# Altium schematic sheet 30082017.SchDoc
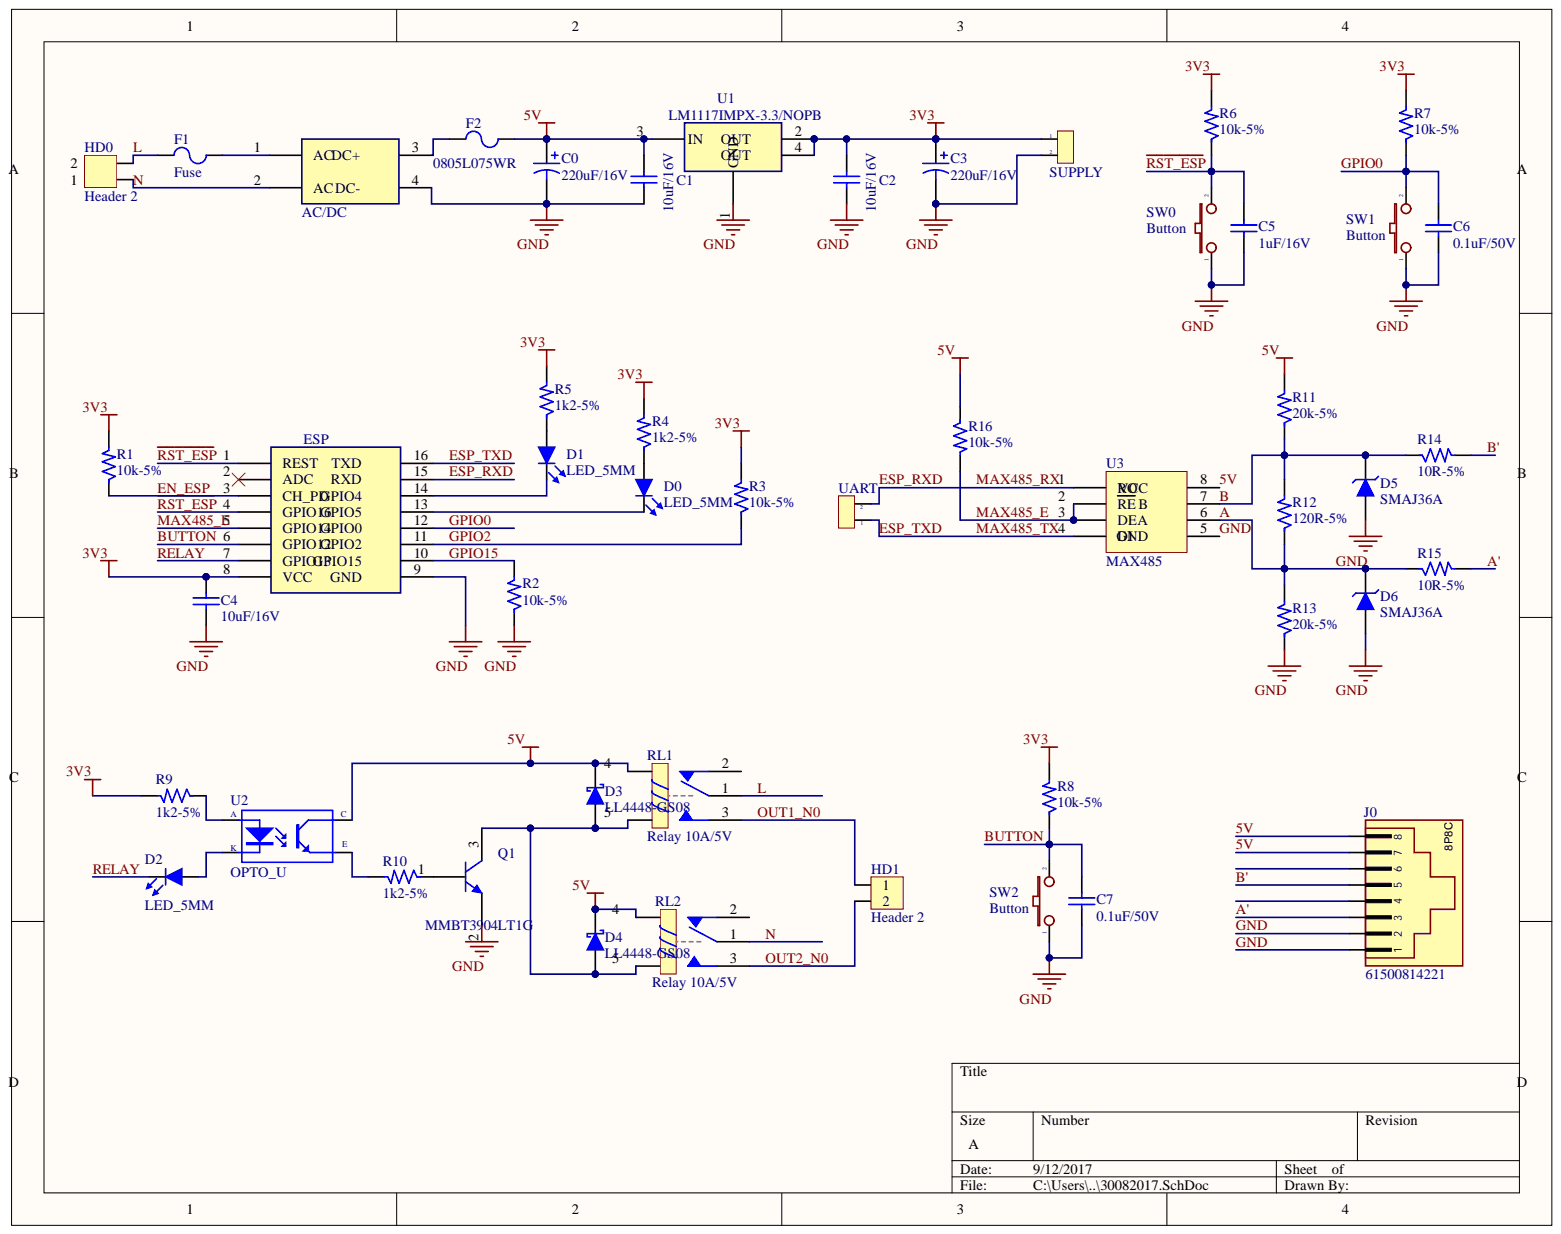
[diagram p0: 30082017.SchDoc]

## Parts
AC/DC  AC/DC_5V_0.7A  [AC/DC_5V_700mA]  (value not drawn)
C0  220uF/16V  [RADIAL_6.3X12]
C1  10uF/16V  [CAP_SMD_0805]
C2  10uF/16V  [CAP_SMD_0805]
C3  220uF/16V  [RADIAL_6.3X12]
C4  10uF/16V  [CAP_SMD_0805]
C5  1uF/16V  [CAP_SMD_0805]
C6  0.1uF/50V  [CAP_SMD_0805]
C7  0.1uF/50V  [CAP_SMD_0805]
D0  LED_5MM  [LED_5mm]
D1  LED_5MM  [LED_5mm]
D2  LED_5MM  [LED_5mm]
D3  LL4448-GS08  [SOD80C]
D4  LL4448-GS08  [SOD80C]
D5  SMAJ36A  [DO-214AC (SMA)]
D6  SMAJ36A  [DO-214AC (SMA)]
ESP  ESP8266_07  [ESP8266_07]  (value not drawn)
F1  Fuse  [RESISTOR 1/4W (STAND)]
F2  0805L075WR  [RES_SMD_0805]
HD0  Header 2  [HED2]
HD1  Header 2  [HED2]
J0  61500814221  PinNumber: 8P8C  [61500814221]
Q1  MMBT3904LT1G  [SOT-23]
R1  10k-5%  [RES_SMD_0805]
R2  10k-5%  [RES_SMD_0805]
R3  10k-5%  [RES_SMD_0805]
R4  1k2-5%  [RES_SMD_0805]
R5  1k2-5%  [RES_SMD_0805]
R6  10k-5%  [RES_SMD_0805]
R7  10k-5%  [RES_SMD_0805]
R8  10k-5%  [RES_SMD_0805]
R9  1k2-5%  [RES_SMD_0805]
R10  1k2-5%  [RES_SMD_0805]
R11  20k-5%  [RES_SMD_0805]
R12  120R-5%  [RES_SMD_0805]
R13  20k-5%  [RES_SMD_0805]
R14  10R-5%  [RES_SMD_0805]
R15  10R-5%  [RES_SMD_0805]
R16  10k-5%  [RES_SMD_0805]
RL1  Relay 10A/5V  [RELAY5PIN-10A]
RL2  Relay 10A/5V  [RELAY5PIN-10A]
SUPPLY  Jumper 2  [JP2]  (value not drawn)
SW0  Button  [SW2]
SW1  Button  [SW2]
SW2  Button  [SW2]
U1  LM1117IMPX-3.3/NOPB  [SOT223]
U2  OPTO_U  [DIP4/W0.3]
U3  MAX485  [SO-8]
UART  Jumper 2  [JP2]  (value not drawn)

## Nets
3V3: C2.2 C3.1 C4.2 ESP.8(VCC) R1.2 R3.2 R4.2 R5.2 R6.2 R7.2 R8.2 R9.2 SUPPLY.1 U1.2 U1.4
5V: C0.1 C1.2 D3.2 D4.2 F2.2 J0.7 J0.8 R11.2 R16.1 RL1.4 RL2.4 U1.3(IN) U2.4 U3.8
A: D6.2 R12.1 R13.2 R15.1 U3.6
A': J0.3 R15.2
B: D5.2 R11.1 R12.2 R14.1 U3.7
B': J0.5 R14.2
BUTTON: C7.2 ESP.6(GPIO12) R8.1 SW2.2
EN_ESP: ESP.3(CH_PD) R1.1
ESP_RXD: ESP.15 U3.1(RO) UART.2
ESP_TXD: ESP.16 U3.4(GND) UART.1
GND: AC/DC.4 C0.2 C1.1 C2.1 C3.2 C4.1 C5.1 C6.1 C7.1 D5.1 D6.1 ESP.9 J0.1 J0.2 Q1.2 R2.1 R13.1 SUPPLY.2 SW0.1 SW1.1 SW2.1 U1.1(GND) U3.5
GPIO0: C6.2 ESP.12 R7.1 SW1.2
GPIO2: ESP.11 R3.1
GPIO15: ESP.10 R2.2
L: F1.1 HD0.2 RL1.1
MAX485_E: ESP.5(GPIO14) R16.2 U3.2(RE_B) U3.3(DE_A)
N: AC/DC.2(AC_DC-) HD0.1 RL2.1
OUT1_N0: HD1.1 RL1.3
OUT2_N0: HD1.2 RL2.3
~{RST_ESP}: C5.2 ESP.1(REST) ESP.4(GPIO16) R6.1 SW0.2
RELAY: D2.2 ESP.7(GPIO13)
NetAC/DC_1: AC/DC.1(AC) F1.2
NetAC/DC_3: AC/DC.3 F2.1
NetD0_1: D0.1 R4.1
NetD0_2: D0.2 ESP.13
NetD1_1: D1.1 R5.1
NetD1_2: D1.2 ESP.14
NetD2_1: D2.1 U2.2
NetD3_1: D3.1 D4.1 Q1.3 RL1.5 RL2.5
NetQ1_1: Q1.1 R10.1
NetR9_1: R9.1 U2.1
NetR10_2: R10.2 U2.3

Not connected: ESP 2(ADC); J0 4 6; RL1 2; RL2 2

## Library data of the parts
AC/DC = AC/DC_5V_0.7A: AC/DC Converter 5V 700mA; Component Kind: Standard; ComponentLink1URL: http://www.dientuachau.com/ac-dc-5v-700; Library Name: LIF_DIF_Library.IntLib
C0 C3 = 100uF/25V: CAP ALUM 100UF 20% 25V RADIAL; Manufacturer: Panasonic Electronic Components; Manufacturer Part Number: ECA-1EM101; Capacitance: 100µF; Lifetime @ Temp.: 2000 Hrs @ 85°C; Polarization: Polar; Ripple Current - High Frequency: 306mA @ 10kHz; Ripple Current - Low Frequency: 180mA @ 120Hz; Applications: General Purpose; Category: Capacitors; Component Kind: Standard; ComponentLink1Description: https://industrial.panasonic.com/cdbs/www-data/pdf/RDF0000/ABA0000C1218.pdf; ComponentLink1URL: https://industrial.panasonic.com/cdbs/www-data/pdf/RDF0000/ABA0000C1218.pdf; ESR (Equivalent Series Resistance): -; Height - Seated (Max): 0.480" (12.20mm); Impedance: -; Lead Spacing: 0.098" (2.50mm); Mounting Type: Through Hole; Operating Temperature: -40°C ~ 85°C; Package / Case: Radial, Can; Packaging: Bulk; Part Status: Active; Pricing 1: 1=0.28, 10=0.191, 100=0.097, 500=0.06634, 1000=0.05868, 2500=0.05613, 5000=0.05103 (USD); RoHS: RoHS Compliant; Size / Dimension: 0.248" Dia (6.30mm); Stock 1: 8044; Supplier 1: Digi-Key; Supplier Part Number 1: P5152-ND; Surface Mount Land Size: -; Tolerance: ±20%; Voltage Rating: 25V; Library Name: LIF_DIF_Library.IntLib
C1 C2 C4 = 10uF/16V-10%-0805: CAP CER 10UF 16V X5R 0805; Manufacturer: Yageo; Manufacturer Part Number: CC0805KKX5R7BB106; Capacitance: 10µF; Tolerance: ±10%; Applications: General Purpose; Category: Capacitors; Component Kind: Standard; ComponentLink1Description: http://www.yageo.com/documents/recent/UPY-GPHC_X5R_4V-to-50V-21.pdf; ComponentLink1URL: http://www.yageo.com/documents/recent/UPY-GPHC_X5R_4V-to-50V-21.pdf; Features: -; Height - Seated (Max): -; Lead Spacing: -; Lead Style: -; Mounting Type: Surface Mount, MLCC; Operating Temperature: -55°C ~ 85°C; Package / Case: 0805 (2012 Metric); Packaging: Cut Tape (CT); Pricing 1: 1=0.21, 10=0.141, 25=0.102, 100=0.0715, 250=0.05792, 500=0.0511, 1000=0.04343 (USD); Ratings: -; RoHS: RoHS Compliant; Size / Dimension: 0.079" L x 0.049" W (2.00mm x 1.25mm); Stock 1: 114; Supplier 1: Digi-Key; Supplier Part Number 1: 311-1865-1-ND; Temperature Coefficient: X5R; Thickness (Max): 0.057" (1.45mm); Voltage - Rated: 16V; Library Name: LIF_DIF_Library.IntLib
C5 = 1uF/16V-10%-0805: CAP CER 1UF 16V X7R 0805; Manufacturer: Yageo; Manufacturer Part Number: CC0805KKX7R7BB105; Capacitance: 1µF; Tolerance: ±10%; Applications: General Purpose; Category: Capacitors; Component Kind: Standard; ComponentLink1Description: http://www.yageo.com/documents/recent/UPY-GPHC_X7R_6.3V-to-50V_14.pdf; ComponentLink1URL: http://www.yageo.com/documents/recent/UPY-GPHC_X7R_6.3V-to-50V_14.pdf; Features: -; Height - Seated (Max): -; Lead Spacing: -; Lead Style: -; Mounting Type: Surface Mount, MLCC; Operating Temperature: -55°C ~ 125°C; Package / Case: 0805 (2012 Metric); Packaging: Cut Tape (CT); Pricing 1: 1=0.1, 10=0.069, 25=0.0492, 100=0.0318, 250=0.026, 500=0.02224, 1000=0.01733 (USD); Ratings: -; RoHS: RoHS Compliant; Size / Dimension: 0.079" L x 0.049" W (2.00mm x 1.25mm); Stock 1: 48; Supplier 1: Digi-Key; Supplier Part Number 1: 311-1365-1-ND; Temperature Coefficient: X7R; Thickness (Max): 0.049" (1.25mm); Voltage - Rated: 16V; Library Name: LIF_DIF_Library.IntLib
C6 C7 = 0.1uF/50V-10%-0805: CAP CER 0.1UF 50V X7R 0805; Manufacturer: Yageo; Manufacturer Part Number: CC0805KRX7R9BB104; Capacitance: 0.10µF; Tolerance: ±10%; Applications: General Purpose; Category: Capacitors; Component Kind: Standard; ComponentLink1Description: http://www.yageo.com/documents/recent/UPY-GPHC_X7R_6.3V-to-50V_14.pdf; ComponentLink1URL: http://www.yageo.com/documents/recent/UPY-GPHC_X7R_6.3V-to-50V_14.pdf; Features: -; Height - Seated (Max): -; Lead Spacing: -; Lead Style: -; Mounting Type: Surface Mount, MLCC; Operating Temperature: -55°C ~ 125°C; Package / Case: 0805 (2012 Metric); Packaging: Cut Tape (CT); Pricing 1: 1=0.1, 10=0.051, 25=0.0356, 100=0.0232, 250=0.01896, 500=0.01622, 1000=0.01263 (USD); Ratings: -; RoHS: RoHS Compliant; Size / Dimension: 0.079" L x 0.049" W (2.00mm x 1.25mm); Stock 1: 931677; Supplier 1: Digi-Key; Supplier Part Number 1: 311-1140-1-ND; Temperature Coefficient: X7R; Thickness (Max): 0.033" (0.85mm); Voltage - Rated: 50V; Library Name: LIF_DIF_Library.IntLib
D0-D2 = LED_5MM: LED BLUE 5MM; Manufacturer: Lite-On Inc; Manufacturer Part Number: LTST-C171TBKT; Component Kind: Standard; Digi-Key Part Number: 160-1645-1-ND; Unit Price: 0.08100; Library Name: LIF_DIF_Library.IntLib
D3 D4 = LL4448-GS08: DIODE GEN PURP 75V 150MA SOD80; Manufacturer: Vishay Semiconductor Diodes Division; Capacitance @ Vr, F: 4pF @ 0V, 1MHz; Current - DC Forward (If) (Max): 10mA; Current - Output / Channel: 50mA; Current - Reverse Leakage @ Vr: 5µA @ 75V; Current Transfer Ratio (Max): 600% @ 500µA; Current Transfer Ratio (Min): 100% @ 500µA; Diode Type: Standard; Input Type: DC; Number of Channels: 1; Output Type: Transistor; Rise / Fall Time (Typ): 4µs, 3µs; Speed: Small Signal =< 200mA (Io), Any Speed; Vce Saturation (Max): 200mV; Voltage - Forward (Vf) (Max) @ If: 720mV @ 5mA; Voltage - Forward (Vf) (Typ): 1.2V; Voltage - Isolation: 5000Vrms; Voltage - Output (Max): 80V; Category: Discrete Semiconductor Products; Component Kind: Standard; ComponentLink1Description: http://www.vishay.com/docs/85557/ll4148.pdf; ComponentLink1URL: http://www.vishay.com/docs/85557/ll4148.pdf; ComponentLink2Description: https://cdn.sharpsde.com/fileadmin/products/Optoelectronics/Isolation%20Devices/Specs%20Photocoupler/PC8171xNIP0X_24Nov09_Spec_ED-09P026A.pdf; ComponentLink2URL: https://cdn.sharpsde.com/fileadmin/products/Optoelectronics/Isolation%20Devices/Specs%20Photocoupler/PC8171xNIP0X_24Nov09_Spec_ED-09P026A.pdf; Current - Average Rectified (Io): 150mA; Manufacturer Part Number: LL4448-GS08; Mounting Type: Surface Mount; Operating Temperature: -30°C ~ 100°C; Operating Temperature - Junction: -65°C ~ 175°C; Package / Case: DO-213AC, MINI-MELF, SOD-80; Packaging: Cut Tape (CT); Part Status: Active; Pricing 1: 1=0.15, 10=0.133, 25=0.1272, 100=0.0727, 250=0.05388, 500=0.04472, 1000=0.03049 (USD); Pricing 2: 1=0.89, 10=0.693, 25=0.5744, 100=0.495, 250=0.4356, 500=0.3762, 1000=0.297 (USD); RoHS: RoHS Compliant; Stock 1: 32666; Stock 2: 39900; Supplier 1: Digi-Key; Supplier 2: Digi-Key; Supplier Device Package: SOD-80 MiniMELF; Supplier Part Number 1: LL4448-GS08CT-ND; Supplier Part Number 2: 425-2753-1-ND; Turn On / Turn Off Time (Typ): -; Voltage - DC Reverse (Vr) (Max): 75V; Library Name: LIF_DIF_Library.IntLib
D5 D6 = SMAJ36A: TVS DIODE 36VWM 58.1VC SMA; Manufacturer: Littelfuse Inc.; Current - Peak Pulse (10/1000µs): 6.9A; Power - Peak Pulse: 400W; Power Line Protection: No; Type: Zener; Voltage - Breakdown (Min): 40V; Voltage - Clamping (Max) @ Ipp: 58.1V; Voltage - Reverse Standoff (Typ): 36V; Applications: General Purpose; Capacitance @ Frequency: -; Category: Circuit Protection; Component Kind: Standard; ComponentLink1Description: http://www.littelfuse.com/~/media/electronics/datasheets/tvs_diodes/littelfuse_tvs_diode_smaj_datasheet.pdf.pdf; ComponentLink1URL: http://www.littelfuse.com/~/media/electronics/datasheets/tvs_diodes/littelfuse_tvs_diode_smaj_datasheet.pdf.pdf; Manufacturer Part Number: SMAJ36A; Mounting Type: Surface Mount; Operating Temperature: -55°C ~ 150°C (TJ); Package / Case: DO-214AC, SMA; Packaging: Cut Tape (CT); Part Status: Active; Pricing 1: 1=0.49, 10=0.386, 25=0.3256, 100=0.2652, 250=0.21968, 500=0.18182, 1000=0.13636, 2500=0.125 (USD); RoHS: RoHS Compliant; Stock 1: 47533; Supplier 1: Digi-Key; Supplier Device Package: DO-214AC (SMA); Supplier Part Number 1: SMAJ36ALFCT-ND; Library Name: LIF_DIF_Library.IntLib
ESP = ESP8266_07: ESP8266 SMT MODULE; Manufacturer: Adafruit Industries LLC; Manufacturer Part Number: 2491; Antenna Type: Integrated, Trace; Current - Receiving: 50mA ~ 56mA; Current - Transmitting: 120mA ~ 170mA; Data Rate: 72.2Mbps; Frequency: 2.4GHz; Power - Output: 20.5dBm; Protocol: 802.11b/g/n; RF Family/Standard: WiFi; Sensitivity: -98dBm; Serial Interfaces: I²C, I²S, SPI, UART; Voltage - Supply: 3 V ~ 3.6 V; Category: RF/IF and RFID; Component Kind: Standard; ComponentLink1Description: https://www.adafruit.com/images/product-files/2471/0A-ESP8266__Datasheet__EN_v4.3.pdf; ComponentLink1URL: https://www.adafruit.com/images/product-files/2471/0A-ESP8266__Datasheet__EN_v4.3.pdf; Memory Size: -; Modulation: -; Mounting Type: Surface Mount; Operating Temperature: -40°C ~ 125°C; Package / Case: Module; Packaging: Bulk; Part Status: Active; Pricing 1: 1=6.95 (USD); RoHS: RoHS Compliant; Stock 1: 152; Supplier 1: Digi-Key; Supplier Part Number 1: 1528-1438-ND; Library Name: LIF_DIF_Library.IntLib
F1 = Fuse_AC: Fuse 500mA; Component Kind: Standard; Library Name: LIF_DIF_Library.IntLib
F2 = Fuse_0805L075WR: PTC RESETTABLE 6V .750A SMD 0805; Manufacturer: Littelfuse Inc.; Approvals: cURus, TUV; Current - Max: 40A; Resistance - Initial (Ri) (Min): 90 mOhm; Resistance - Post Trip (R1) (Max): 350 mOhm; Time to Trip: 200ms; Type: Polymeric; Category: Circuit Protection; Component Kind: Standard; ComponentLink1Description: http://www.littelfuse.com/~/media/electronics/datasheets/resettable_ptcs/littelfuse_ptc_0805l_datasheet.pdf.pdf; ComponentLink1URL: http://www.littelfuse.com/~/media/electronics/datasheets/resettable_ptcs/littelfuse_ptc_0805l_datasheet.pdf.pdf; Height - Seated (Max): -; Lead Spacing: -; Manufacturer Part Number: 0805L075WR; Mounting Type: Surface Mount; Operating Temperature: -40°C ~ 85°C; Package / Case: 0805 (2012 Metric), Concave; Packaging: Cut Tape (CT); Part Status: Active; Pricing 1: 1=2.54, 5=2.422, 10=2.3, 50=1.8562, 100=1.5737, 250=1.53332, 500=1.2912, 1000=1.14998 (USD); Ratings: -; Resistance - 25°C (Typ): -; RoHS: RoHS Compliant; Size / Dimension: 0.083" L x 0.053" W (2.10mm x 1.35mm); Stock 1: 5069; Supplier 1: Digi-Key; Supplier Part Number 1: F2773CT-ND; Thickness (Max): 0.030" (0.75mm); Voltage - Max: 6V; Library Name: LIF_DIF_Library.IntLib
HD0 HD1 = Hed2: Header 2 (Green); Component Kind: Standard; Value: Header 2; Library Name: syhaunguyen.SchLib
J0 = 61500814221: WR-MJ Modular Jack Horizontal Shielded 8P8C Tab Down; Component Kind: Standard; ComponentLink1Description: Manufacturer; ComponentLink1URL: http://www.we-online.de; ComponentLink2Description: Datasheet; ComponentLink2URL: http://katalog.we-online.de/em/datasheet/61500814221.pdf; PartNumber: 61500814221; PublishedDate: July 2016; Library Name: ALTIUM-MJ_HORIZONTAL_PLASTIC-rev16a.IntLib; Publisher: Wurth Elektronik
Q1 = MMBT3904LT1G: TRANS NPN 40V 0.2A SOT23; Manufacturer: ON Semiconductor; Current - Collector (Ic) (Max): 200mA; DC Current Gain (hFE) (Min) @ Ic, Vce: 100 @ 10mA, 1V; Frequency - Transition: 300MHz; Power - Max: 300mW; Vce Saturation (Max) @ Ib, Ic: 300mV @ 5mA, 50mA; Category: Discrete Semiconductor Products; Component Kind: Standard; ComponentLink1Description: http://www.onsemi.com/pub_link/Collateral/MMBT3904LT1-D.PDF; ComponentLink1URL: http://www.onsemi.com/pub_link/Collateral/MMBT3904LT1-D.PDF; Current - Collector Cutoff (Max): -; Manufacturer Part Number: MMBT3904LT1G; Mounting Type: Surface Mount; Operating Temperature: -55°C ~ 150°C (TJ); Package / Case: TO-236-3, SC-59, SOT-23-3; Packaging: Digi-Reel®; Part Status: Active; Pricing 1: 1=0.11, 10=0.103, 100=0.0562, 500=0.03456, 1000=0.02356 (USD); RoHS: RoHS Compliant; Stock 1: 132222; Supplier 1: Digi-Key; Supplier Device Package: SOT-23-3 (TO-236); Supplier Part Number 1: MMBT3904LT1GOSDKR-ND; Transistor Type: NPN; Voltage - Collector Emitter Breakdown (Max): 40V; Library Name: LIF_DIF_Library.IntLib
R1-R3 R6-R8 R16 = 10k-5%-0805: RES SMD 10K OHM 5% 1/8W 0805; Manufacturer: Yageo; Manufacturer Part Number: RC0805JR-0710KL; Power (Watts): 0.125W, 1/8W; Temperature Coefficient: ±100ppm/°C; Category: Resistors; Component Kind: Standard; ComponentLink1Description: http://www.yageo.com/documents/recent/PYu-RC_Group_51_RoHS_L_7.pdf; ComponentLink1URL: http://www.yageo.com/documents/recent/PYu-RC_Group_51_RoHS_L_7.pdf; Composition: Thick Film; Failure Rate: -; Features: Moisture Resistant; Height - Seated (Max): 0.024" (0.60mm); Operating Temperature: -55°C ~ 155°C; Package / Case: 0805 (2012 Metric); Packaging: Cut Tape (CT); Part Status: Active; Pricing 1: 1=0.1, 10=0.018, 100=0.0073, 1000=0.00326, 2500=0.00283 (USD); Resistance (Ohms): 10k; RoHS: RoHS Compliant; Size / Dimension: 0.079" L x 0.049" W (2.00mm x 1.25mm); Stock 1: 1331399; Supplier 1: Digi-Key; Supplier Device Package: 0805; Supplier Part Number 1: 311-10KARCT-ND; Tolerance: ±5%
  R16: Library Name: RES_SMD_0805 (1).SchLib
  R1-R3 R6-R8: Library Name: LIF_DIF_Library.IntLib
R4 R5 R9 R10 = 1k2-5%-0805: RES SMD 1.2K OHM 5% 1/8W 0805; Manufacturer: Yageo; Manufacturer Part Number: RC0805JR-071K2L; Power (Watts): 0.125W, 1/8W; Temperature Coefficient: ±100ppm/°C; Category: Resistors; Component Kind: Standard; ComponentLink1Description: http://www.yageo.com/documents/recent/PYu-RC_Group_51_RoHS_L_7.pdf; ComponentLink1URL: http://www.yageo.com/documents/recent/PYu-RC_Group_51_RoHS_L_7.pdf; Composition: Thick Film; Failure Rate: -; Features: Moisture Resistant; Height - Seated (Max): 0.024" (0.60mm); Operating Temperature: -55°C ~ 155°C; Package / Case: 0805 (2012 Metric); Packaging: Cut Tape (CT); Part Status: Active; Pricing 1: 1=0.1, 10=0.018, 100=0.0073, 1000=0.00326, 2500=0.00283 (USD); Resistance (Ohms): 1.2k; RoHS: RoHS Compliant; Size / Dimension: 0.079" L x 0.049" W (2.00mm x 1.25mm); Stock 1: 85871; Supplier 1: Digi-Key; Supplier Device Package: 0805; Supplier Part Number 1: 311-1.2KARCT-ND; Tolerance: ±5%; Library Name: LIF_DIF_Library.IntLib
R11 R13 = 20k-5%-0805: RES SMD 20K OHM 5% 1/8W 0805; Manufacturer: Yageo; Manufacturer Part Number: RC0805JR-0720KL; Power (Watts): 0.125W, 1/8W; Temperature Coefficient: ±100ppm/°C; Category: Resistors; Component Kind: Standard; ComponentLink1Description: http://www.yageo.com/documents/recent/PYu-RC_Group_51_RoHS_L_7.pdf; ComponentLink1URL: http://www.yageo.com/documents/recent/PYu-RC_Group_51_RoHS_L_7.pdf; Composition: Thick Film; Failure Rate: -; Features: Moisture Resistant; Height - Seated (Max): 0.024" (0.60mm); Library Name: RES_SMD_0805 (1).SchLib; Operating Temperature: -55°C ~ 155°C; Package / Case: 0805 (2012 Metric); Packaging: Cut Tape (CT); Part Status: Active; Pricing 1: 1=0.1, 10=0.018, 100=0.0073, 1000=0.00326, 2500=0.00283 (USD); Resistance (Ohms): 20k; RoHS: RoHS Compliant; Size / Dimension: 0.079" L x 0.049" W (2.00mm x 1.25mm); Stock 1: 145711; Supplier 1: Digi-Key; Supplier Device Package: 0805; Supplier Part Number 1: 311-20KARCT-ND; Tolerance: ±5%
R12 = 120R-5%-0805: RES SMD 120 OHM 5% 1/8W 0805; Manufacturer: Yageo; Manufacturer Part Number: RC0805JR-07120RL; Power (Watts): 0.125W, 1/8W; Resistance (Ohms): 120; Temperature Coefficient: ±100ppm/°C; Category: Resistors; Component Kind: Standard; ComponentLink1Description: http://www.yageo.com/documents/recent/PYu-RC_Group_51_RoHS_L_7.pdf; ComponentLink1URL: http://www.yageo.com/documents/recent/PYu-RC_Group_51_RoHS_L_7.pdf; Composition: Thick Film; Failure Rate: -; Features: Moisture Resistant; Height - Seated (Max): 0.024" (0.60mm); Library Name: RES_SMD_0805 (1).SchLib; Operating Temperature: -55°C ~ 155°C; Package / Case: 0805 (2012 Metric); Packaging: Cut Tape (CT); Part Status: Active; Pricing 1: 1=0.1, 10=0.018, 100=0.0073, 1000=0.00326, 2500=0.00283 (USD); RoHS: RoHS Compliant; Size / Dimension: 0.079" L x 0.049" W (2.00mm x 1.25mm); Stock 1: 186870; Supplier 1: Digi-Key; Supplier Device Package: 0805; Supplier Part Number 1: 311-120ARCT-ND; Tolerance: ±5%
R14 R15 = 10R-5%-0805: RES SMD 10 OHM 5% 1/8W 0805; Manufacturer: Yageo; Manufacturer Part Number: RC0805JR-0710RL; Power (Watts): 0.125W, 1/8W; Resistance (Ohms): 10; Temperature Coefficient: ±200ppm/°C; Category: Resistors; Component Kind: Standard; ComponentLink1Description: http://www.yageo.com/documents/recent/PYu-RC_Group_51_RoHS_L_7.pdf; ComponentLink1URL: http://www.yageo.com/documents/recent/PYu-RC_Group_51_RoHS_L_7.pdf; Composition: Thick Film; Failure Rate: -; Features: Moisture Resistant; Height - Seated (Max): 0.024" (0.60mm); Operating Temperature: -55°C ~ 155°C; Package / Case: 0805 (2012 Metric); Packaging: Cut Tape (CT); Part Status: Active; Pricing 1: 1=0.1, 10=0.018, 100=0.0073, 1000=0.00326, 2500=0.00283 (USD); RoHS: RoHS Compliant; Size / Dimension: 0.079" L x 0.049" W (2.00mm x 1.25mm); Stock 1: 371358; Supplier 1: Digi-Key; Supplier Device Package: 0805; Supplier Part Number 1: 311-10ARCT-ND; Tolerance: ±5%; Library Name: LIF_DIF_Library.IntLib
RL1 RL2 = Relay 10A: Relay SPDT,10A - 12VDC 5 Pin; Value: Relay SPDT, 10A, 12V; : Relay SPDT, 10A, 24V; Component Kind: Standard; Library Name: LIF_DIF_Library.IntLib
SUPPLY UART = JP 2: Value: JP 2; Component Kind: Standard; Description: Jumper 2; Library Name: syhaunguyen.SchLib
SW0-SW2 = SW: Switch button 2 pin; Component Kind: Standard; Name: Button; Library Name: LIF_DIF_Library.IntLib
U1 = LM1117IMPX-3.3/NOPB: IC REG LDO 3.3V 0.8A SOT223; Manufacturer: Texas Instruments; Current - Output: 800mA; Current - Supply: 5mA ~ 10mA; Output Configuration: Positive; Output Type: Fixed; Protection Features: Over Current, Over Temperature; PSRR: 75dB (120Hz); Regulator Topology: Positive Fixed; Voltage - Dropout (Typical): 1.2V @ 800mA; Voltage - Input (Max): 15V; Category: Integrated Circuits (ICs); Component Kind: Standard; ComponentLink1Description: http://www.ti.com/lit/ds/symlink/lm1117.pdf; ComponentLink1URL: http://www.ti.com/lit/ds/symlink/lm1117.pdf; Control Features: -; Manufacturer Part Number: LM1117IMPX-3.3/NOPB; Mounting Type: Surface Mount; Operating Temperature: -40°C ~ 125°C; Package / Case: TO-261-4, TO-261AA; Packaging: Cut Tape (CT); Part Status: Active; Pricing 1: 1=1.04, 10=0.927, 100=0.7225, 500=0.59688, 1000=0.47123 (USD); RoHS: RoHS Compliant; Stock 1: 25761; Supplier 1: Digi-Key; Supplier Device Package: SOT-223-4; Supplier Part Number 1: LM1117IMPX-3.3/NOPBCT-ND; Voltage - Output: 3.3V; Voltage - Output (Max): -; Voltage - Output (Min/Fixed): 3.3V; Voltage Dropout (Max): 1.2V @ 800mA; Library Name: LIF_DIF_Library.IntLib
U2 = OPTO_U: Single Opto; Value: TLP5211; Component Kind: Standard; Library Name: syhaunguyen.SchLib
U3 = MAX485: RS232 - RS485 (Same sn75176); Component Kind: Standard; Library Name: syhaunguyen.SchLib
